# Altium schematic sheet Sheet2.SchDoc
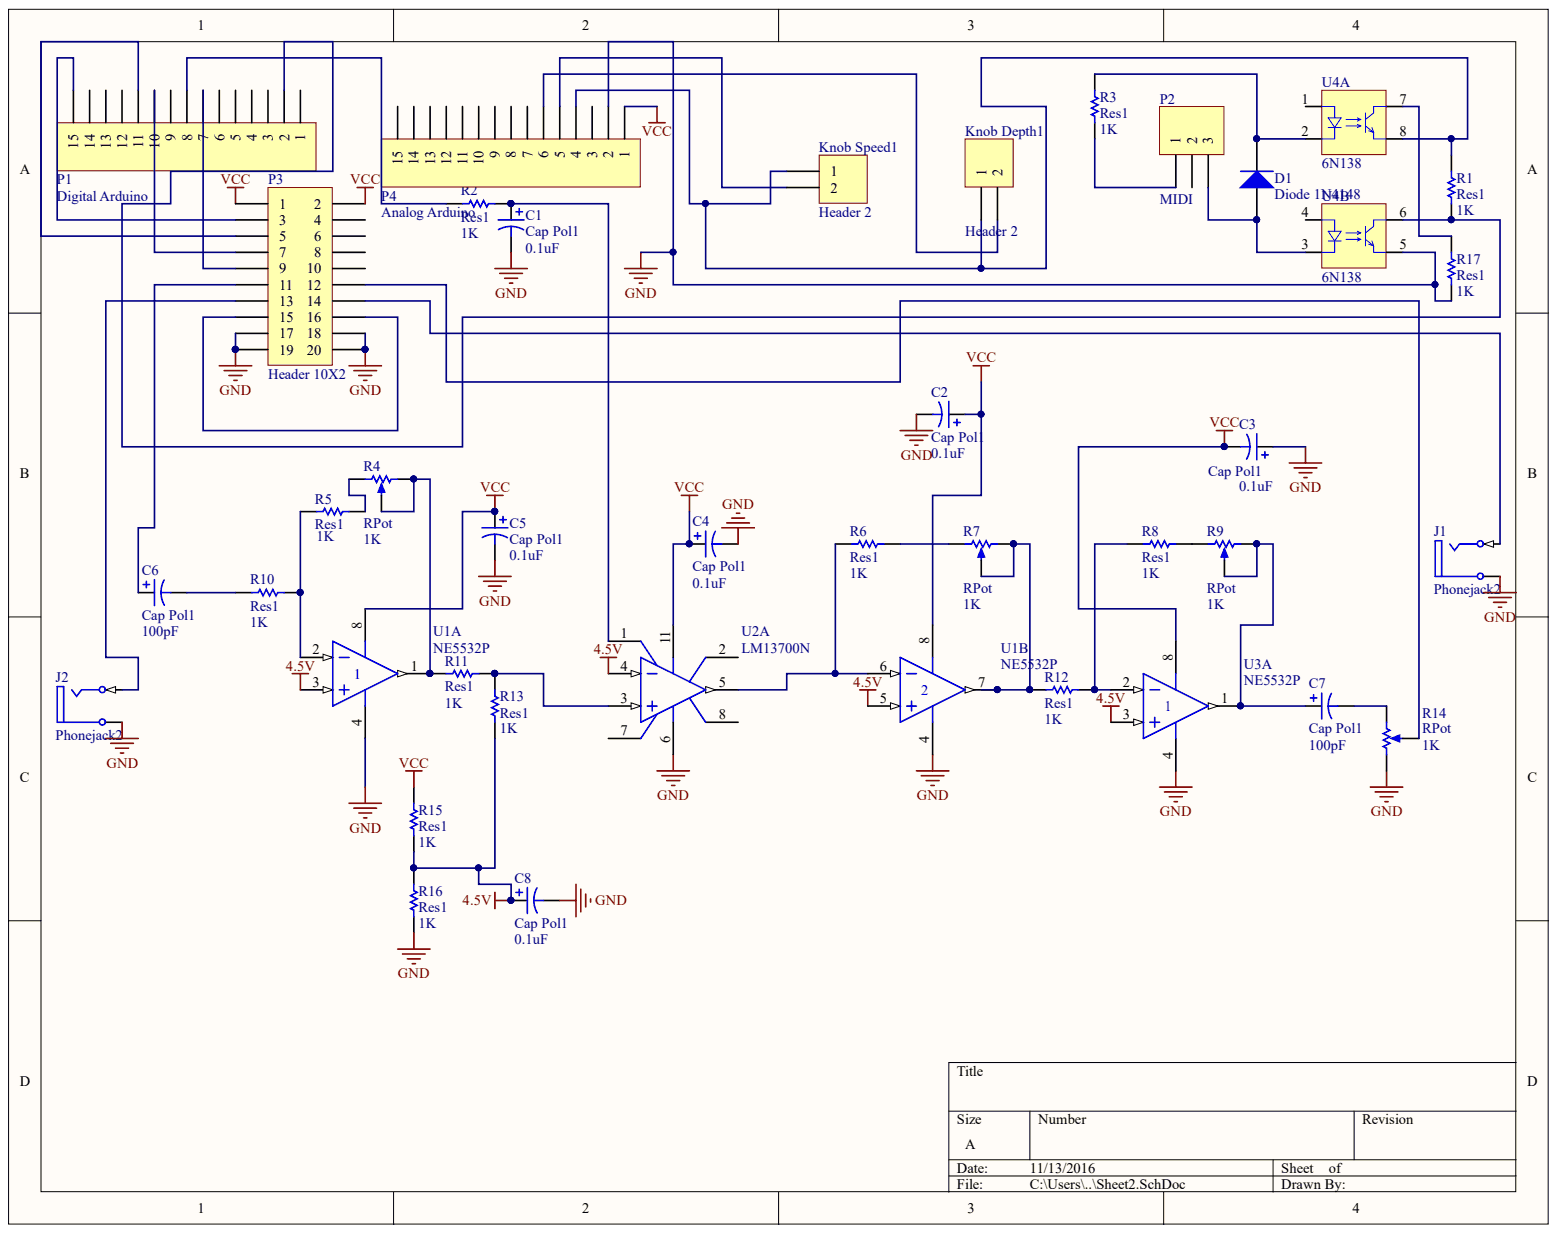
[diagram p0: Sheet2.SchDoc]

## Parts
C1  Cap Pol1  value 0.1uF  [RAD-0.1]
C2  Cap Pol1  value 0.1uF  [RAD-0.1]
C3  Cap Pol1  value 0.1uF  [RAD-0.1]
C4  Cap Pol1  value 0.1uF  [RAD-0.1]
C5  Cap Pol1  value 0.1uF  [RAD-0.1]
C6  Cap Pol1  value 100pF  [RAD-0.1]
C7  Cap Pol1  value 100pF  [RAD-0.1]
C8  Cap Pol1  value 0.1uF  [RAD-0.1]
D1  Diode 1N4148  [DO-35]
J1  Phonejack2  [JACK/6-V2]
J2  Phonejack2  [JACK/6-V2]
Knob_Depth1  Header 2  [HDR1X2]
Knob_Speed1  Header 2  [HDR1X2]
P1  Digital Arduino  [HDR1X15]
P2  MIDI  [HDR1X3]
P3  Header 10X2  [HDR2X10]
P4  Analog Arduino  [HDR1X15]
R1  Res1  value 1K  [AXIAL-0.3]
R2  Res1  value 1K  [AXIAL-0.3]
R3  Res1  value 1K  [AXIAL-0.3]
R4  RPot  value 1K  [VR5]
R5  Res1  value 1K  [AXIAL-0.3]
R6  Res1  value 1K  [AXIAL-0.3]
R7  RPot  value 1K  [VR5]
R8  Res1  value 1K  [AXIAL-0.3]
R9  RPot  value 1K  [VR5]
R10  Res1  value 1K  [AXIAL-0.3]
R11  Res1  value 1K  [AXIAL-0.3]
R12  Res1  value 1K  [AXIAL-0.3]
R13  Res1  value 1K  [AXIAL-0.3]
R14  RPot  value 1K  [VR5]
R15  Res1  value 1K  [AXIAL-0.3]
R16  Res1  value 1K  [AXIAL-0.3]
R17  Res1  value 1K  [AXIAL-0.3]
U1  NE5532P  [P008]  (parts A,B)
U2  LM13700N  [N16E]  (part A)
U3  NE5532P  [P008]  (part A)
U4  6N138  [DIP8]  (parts A,B)

## Nets
4.5V: C8.1 R13.2 R15.1 R16.2 U1.3 U1.5 U2.4 U3.3
GND: C1.2 C2.2 C3.1 C4.2 C5.2 C8.2 J1.5 J2.5 P3.17 P3.18 P3.19 P3.20 P4.2 R14.1 R16.1 R17.1 U1.4 U2.6 U3.4 U4.5
VCC: C2.1 C3.2 C4.1 C5.1 P3.1 P3.2 P4.1 R15.2 U1.8 U2.11 U3.8
NetC1_1: C1.1 R2.2 U2.1
NetC6_1: C6.1 P3.11
NetC6_2: C6.2 R10.1
NetC7_1: C7.1 R9.2 R9.3 U3.1
NetC7_2: C7.2 R14.2
NetD1_1: D1.1 P2.3 U4.3
NetD1_2: D1.2 R3.2 U4.2
NetJ1_1: J1.1 P3.14
NetJ2_1: J2.1 P3.13
NetKnob Depth1_1: Knob_Depth1.1 Knob_Speed1.1 P4.4 R1.2 U4.8
NetKnob Depth1_2: Knob_Depth1.2 P4.6
NetKnob Speed1_2: Knob_Speed1.2 P4.5
NetP1_2: P1.2 R1.1 U4.6
NetP1_7: P1.7 P3.9
NetP1_8: P1.8 R2.1
NetP1_10: P1.10 P3.7
NetP1_11: P1.11 P3.5
NetP1_15: P1.15 P3.3
NetP2_1: P2.1 R3.1
NetP3_12: P3.12 R14.3
NetP3_15: P3.15 P3.16
NetR4_1: R4.1 R5.2
NetR4_2: R4.2 R4.3 R11.1 U1.1
NetR5_1: R5.1 R10.2 U1.2
NetR6_1: R6.1 U1.6 U2.5
NetR6_2: R6.2 R7.1
NetR7_2: R7.2 R7.3 R12.1 U1.7
NetR8_1: R8.1 R12.2 U3.2
NetR8_2: R8.2 R9.1
NetR11_2: R11.2 R13.1 U2.3
NetR17_2: R17.2 U4.7

Not connected: P1 1 3 4 5 6 9 12 13 14; P2 2; P3 4 6 8 10; P4 3 7 8 9 10 11 12 13 14 15; U2 2 7 8; U4 1 4

## Library data of the parts
C1-C8 = Cap Pol1: Polarized Capacitor (Radial); Component Kind: Standard; LatestRevisionDate: 17-Jul-2002; LatestRevisionNote: Re-released for DXP Platform.; Library Name: Miscellaneous Devices.IntLib; PackageDescription: Polarized Capacitor (Radial); 2 Leads; PackageReference: RB7.6-15; Published: 8-Jun-2000; Signal Integrity: Cap Pol; Simulation: CAP; Publisher: Altium Limited
D1 = Diode 1N4148: High Conductance Fast Diode; Code_JEDEC: DO-204-AH; Component Kind: Standard; ComponentLink1Description: Manufacturer Link; ComponentLink1URL: http://www.fairchildsemi.com/; ComponentLink2Description: Datasheet; ComponentLink2URL: http://www.fairchildsemi.com/ds/1N%2F1N4148.pdf; DatasheetVersion: 1997; LatestRevisionDate: 17-Jul-2002; LatestRevisionNote: Re-released for DXP Platform.; Library Name: Miscellaneous Devices.IntLib; PackageDescription: Diode, Axial; Body 3.8 x 1.9 mm (LxDia. max), Lead Dia. 0.53 mm (max); PackageReference: DO-35; Published: 8-Jun-2000; Signal Integrity: 1N4148; Simulation: 1N4148; Publisher: Altium Limited
J1 J2 = Phonejack2: Jack Socket, 1/4" [6.5mm], Thru-Hole, Vertical, 2-Conductor Open Circuit; DrillAndSlotSize: Corresponds to nominal value.; PackageDocument: 2003; PCB_Layout: Complies with manufacturer's recommendation.; Component Kind: Standard; ComponentLink1Description: Manufacturer Link; ComponentLink1URL: http://www.cliffuk.co.uk/; DatasheetDocument: 2003; LatestRevisionDate: 15-Jul-2004; LatestRevisionNote: Component Replaced.; Library Name: Miscellaneous Connectors.IntLib; PackageReference: S1VS_SNS; Signal Integrity: JACK Socket; Publisher: Altium Limited
Knob_Depth1 Knob_Speed1 = Header 2: Header, 2-Pin; Component Kind: Standard; LatestRevisionDate: 17-Jul-2002; LatestRevisionNote: Re-released for DXP Platform.; Library Name: Miscellaneous Connectors.IntLib; Signal Integrity: Connector; Publisher: Altium Limited
P1 P4 = Header 15: Header, 15-Pin; Component Kind: Standard; LatestRevisionDate: 17-Jul-2002; LatestRevisionNote: Re-released for DXP Platform.; Library Name: Miscellaneous Connectors.IntLib; Signal Integrity: Connector; Publisher: Altium Limited
P2 = Header 3: Header, 3-Pin; Component Kind: Standard; LatestRevisionDate: 17-Jul-2002; LatestRevisionNote: Re-released for DXP Platform.; Library Name: Miscellaneous Connectors.IntLib; Signal Integrity: Connector; Publisher: Altium Limited
P3 = Header 10X2: Header, 10-Pin, Dual row; Component Kind: Standard; LatestRevisionDate: 17-Jul-2002; LatestRevisionNote: Re-released for DXP Platform.; Library Name: Miscellaneous Connectors.IntLib; Signal Integrity: Connector; Publisher: Altium Limited
R1-R3 R5 R6 R8 R10 R12 R13 R15-R17 = Res1: Resistor; Component Kind: Standard; LatestRevisionDate: 17-Jul-2002; LatestRevisionNote: Re-released for DXP Platform.; Library Name: Miscellaneous Devices.IntLib; PackageDescription: Axial Device, Thru-Hole; 2 Leads; 0.3 in Pin Spacing; PackageReference: AXIAL-0.3; Published: 8-Jun-2000; Signal Integrity: Res; Simulation: RESISTOR; Publisher: Altium Limited
R4 R7 R9 R14 = RPot: Potentiometer; Set Position: 0.5; Sim Note: Enter the Resistance value and the set point into parameter fields.; Component Kind: Standard; LatestRevisionDate: 17-Jul-2002; LatestRevisionNote: Re-released for DXP Platform.; Library Name: Miscellaneous Devices.IntLib; PackageDescription: Variable Resistor, Thru-Hole; 3 Leads; PackageReference: VR5; Published: 8-Jun-2000; Simulation: POT; Publisher: Altium Limited
R11 = Res1: Resistor; Value: 1K; Component Kind: Standard; LatestRevisionDate: 17-Jul-2002; LatestRevisionNote: Re-released for DXP Platform.; Library Name: Miscellaneous Devices.IntLib; PackageDescription: Axial Device, Thru-Hole; 2 Leads; 0.3 in Pin Spacing; PackageReference: AXIAL-0.3; Published: 8-Jun-2000; Signal Integrity: Res; Simulation: RESISTOR; Publisher: Altium Limited
U1 U3 = NE5532P: Dual Low-Noise Operational Amplifier; Code_JEDEC: MO-001-AN; Component Kind: Standard; ComponentLink1Description: Manufacturer Link; ComponentLink1URL: http://www.ti.com/; ComponentLink2Description: Datasheet; ComponentLink2URL: http://www-s.ti.com/sc/ds/ne5532a.pdf; DatasheetVersion: May-1988; LatestRevisionDate: 04-Mar-2005; LatestRevisionNote: Stylized 3D Model Added.; Library Name: TI Operational Amplifier.IntLib; PackageDescription: DIP; 8 Leads; Row Spacing 7.62 mm; Pitch 2.54 mm; PackageReference: P008; PackageVersion: Jun-1999; PCB3D: P008; Published: 15-Apr-2002; Publisher: Altium Limited
U2 = LM13700N: Dual Operational Transconductance Amplifier with Linearizing Diodes and Buffers, 16-pin MDIP; Manufacturer: National Semiconductor; CompType: Amplifiers; PackageTopMarking: NSUZXYYTTE#*LM13700N; PowerWise: N; Code_JEDEC: MS-001-BB; Component Kind: Standard; ComponentLink1Description: LM13700 Datasheet; ComponentLink1URL: http://www.national.com/ds/LM/LM13700.pdf; ComponentLink2Description: LM13700 Web Page; ComponentLink2URL: http://www.national.com/pf/LM/LM13700.html; ComponentLink3Description: N16E Package Page; ComponentLink3URL: http://www.national.com/packaging/folders/n16e.html; DatasheetVersion: Jun-2004; LatestRevisionDate: 13-Jan-2009; LatestRevisionNote: Sim Model Added.; Library Name: NSC Amplifier.IntLib; PackageDescription: MDIP, .750 x .250 x .130in, 16 Lead, .100in Pitch; PackageReference: N16E; PackageVersion: revG, May-2006; PartNumber: LM13700N; PinCount: 16; Published: 4-Dec-2008; RoHS: N; Simulation: LM13700; Publisher: Altium Limited
U4 = ILD74: Optocoupler, Phototransistor Output, Dual Channel, TTL Compatible, Pb-free; Note: Transfer Ratio, 35 % Typical; Note: Coupling Capacitance, 0.5 pF; Component Kind: Standard; ComponentLink1Description: Manufacturer Link; ComponentLink1URL: http://www.vishay.com/optocouplers/list/product-83640/; ComponentLink2Description: Datasheet; ComponentLink2URL: http://www.vishay.com/docs/83640/83640.pdf; DatasheetDocument: Rev. 1.4, Oct-2004; Library Name: Vishay Optoelectronic Optocoupler.IntLib; PackageDocument: Dec-2003; PackageReference: DIP8; Published: 6-Oct-2005; Publisher: Altium Limited
